# Altium schematic sheet s32k144开发板总图.SchDoc(s32k144开发板总图)
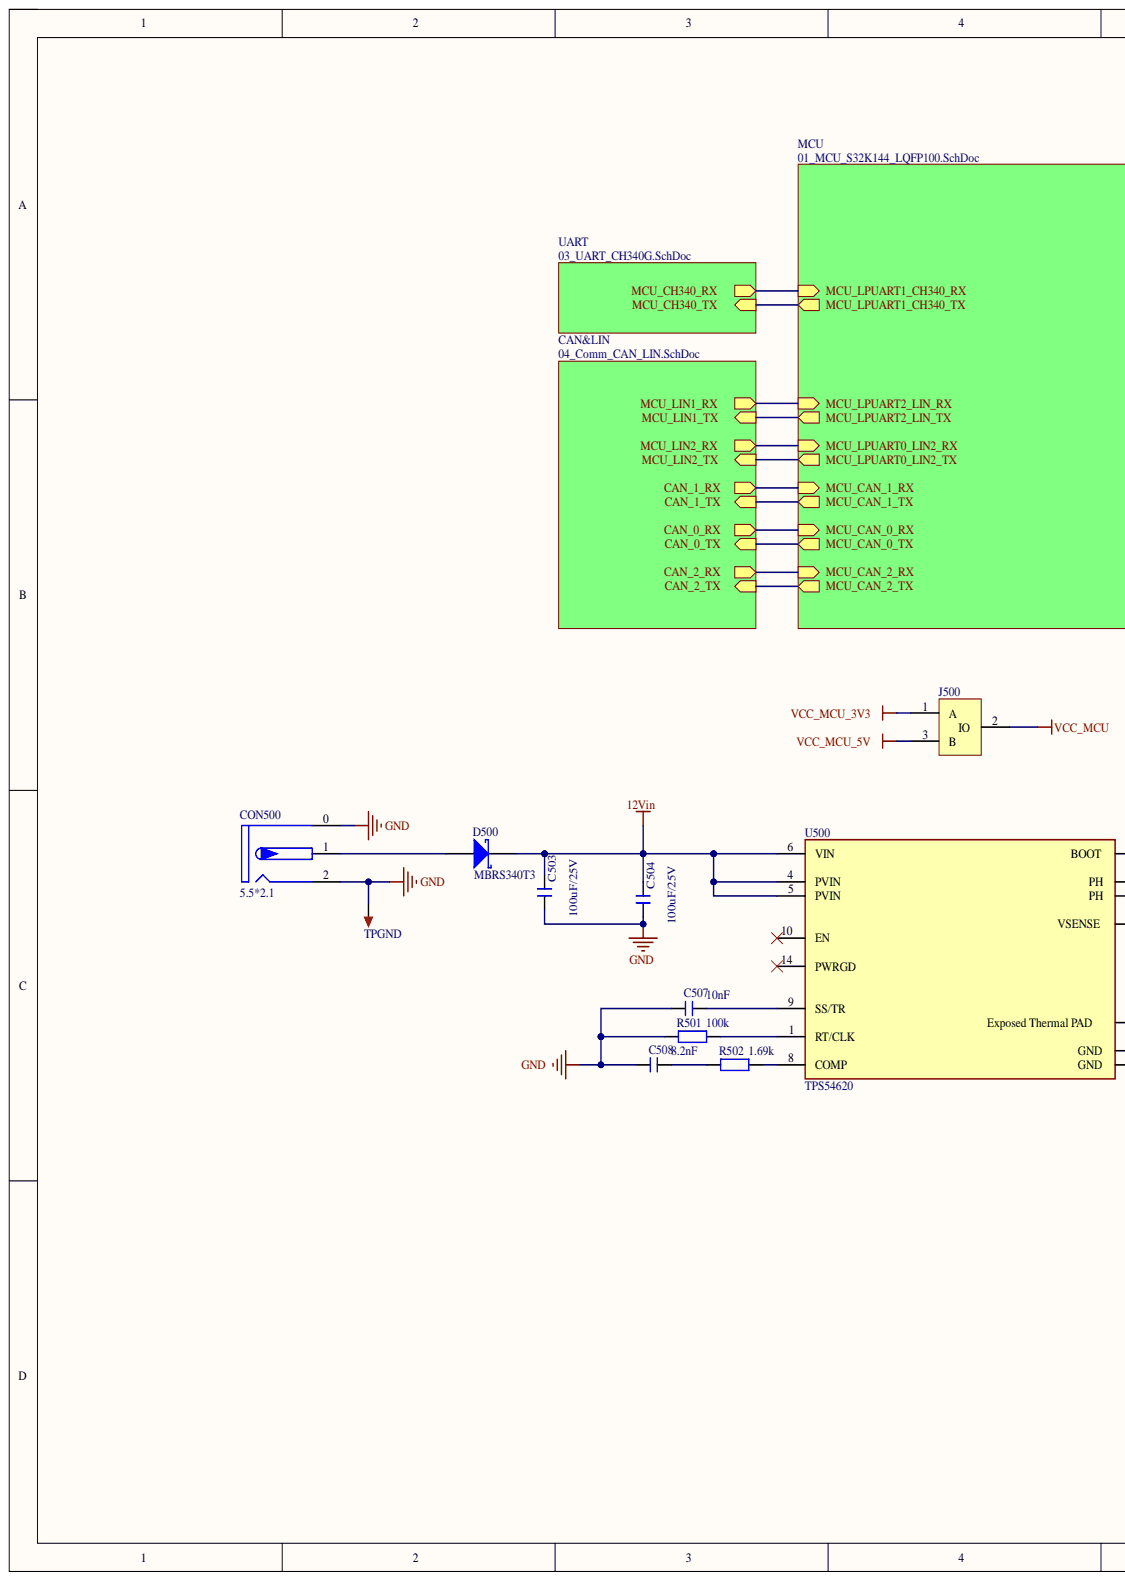
[diagram p0: s32k144开发板总图.SchDoc, left]
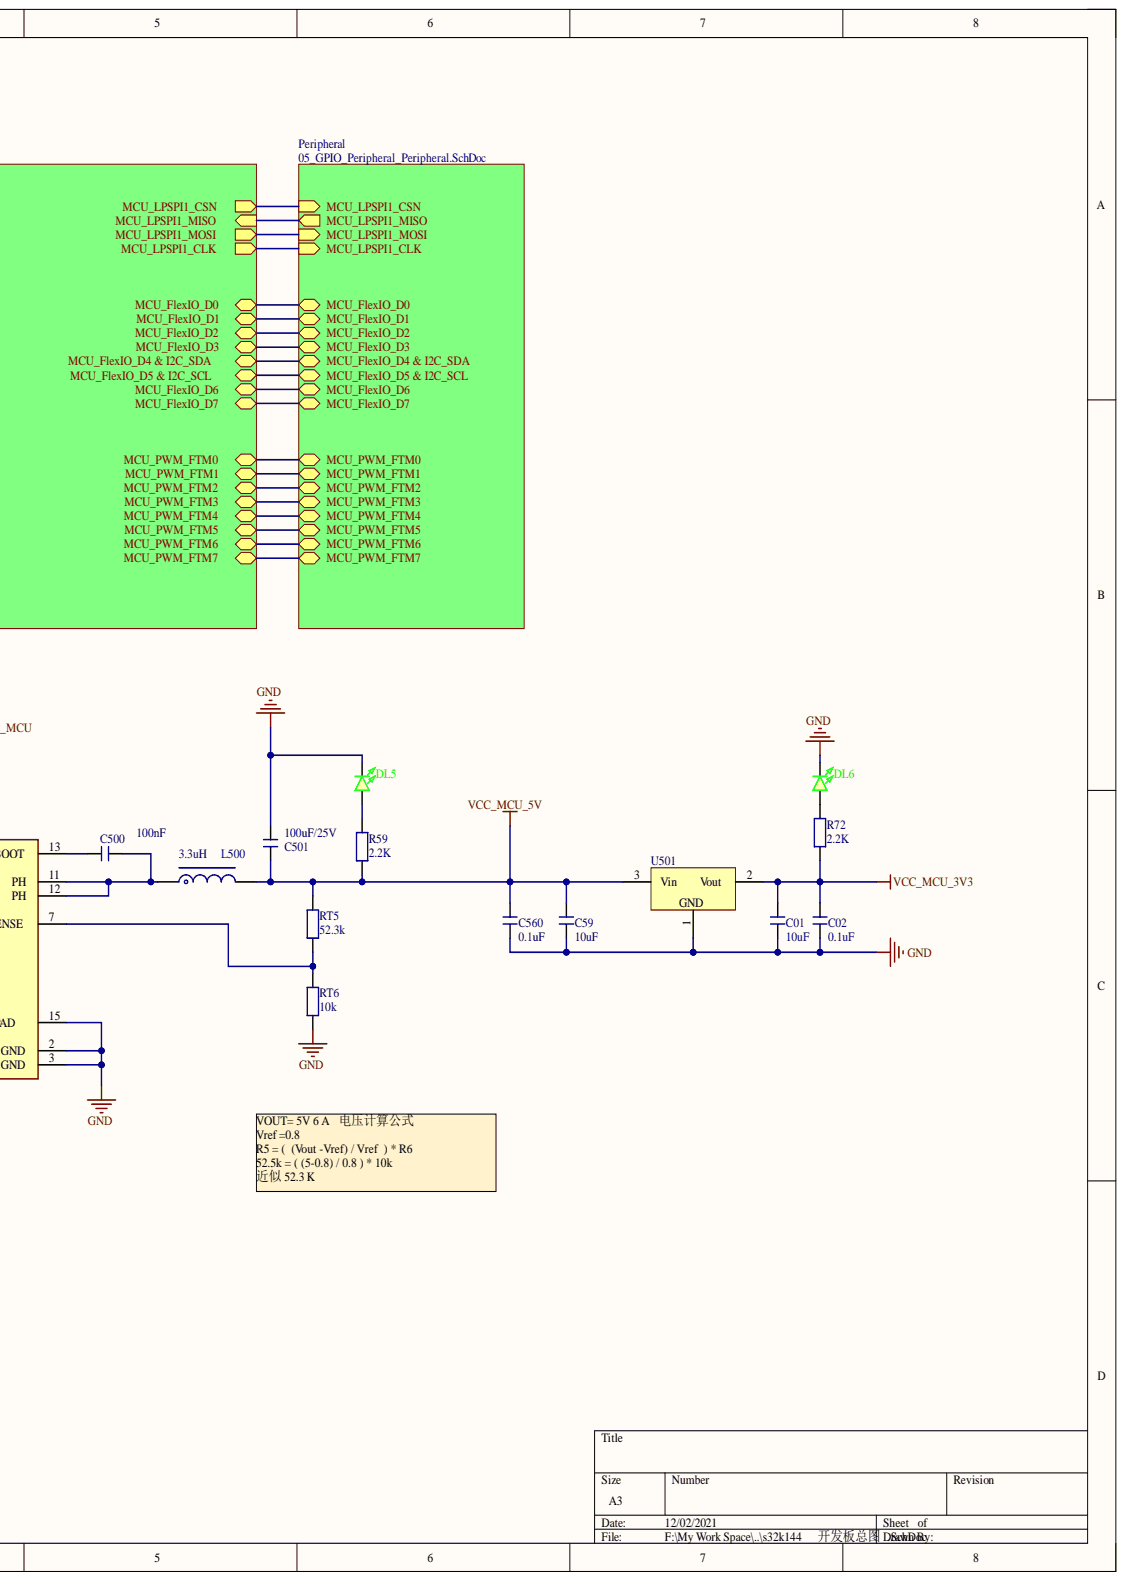
[diagram p1: s32k144开发板总图.SchDoc, right]

## Parts
C01  10uF  [C 0805_L]
C02  0.1uF  [C 0603_L]
C59  10uF  [C 0805_L]
C500  100nF  [C 0603_L]
C501  100uF/25V  [C 1210_L]
C503  100uF/25V  [C 1210_L]
C504  100uF/25V  [C 1210_L]
C507  10nF  [C 0603_L]
C508  8.2nF  [C 0603_L]
C560  0.1uF  [C 0603_L]
CON500  5.5*2.1  [DC-005P]
D500  MBRS340T3  [SMC]
DL5  (no value)  [LED 0603B]
DL6  (no value)  [LED 0603B]
J500  CON3_Jump_1  [HDR2.54-LI-3P]  (value not drawn)
L500  3.3uH  [L-SMD-0630]
R59  2.2K  [R 0603_L]
R72  2.2K  [R 0603_L]
R501  100k  [R 0603_L]
R502  1.69k  [R 0603_L]
RT5  52.3k  [R 0603_L]
RT6  10k  [R 0603_L]
TPGND  TEST TP  [TEST TP1.4_B]  (value not drawn)
U500  TPS54620  [tps54620]
U501  AMS1117-3V3  [SOT223_L]  (value not drawn)

## Nets
12Vin: C503.2 C504.2 D500.2 U500.4(PVIN) U500.5(PVIN) U500.6(VIN)
GND: C01.1 C02.1 C59.1 C501.2 C503.1 C504.1 C507.1 C508.1 C560.1 CON500.0 CON500.2 DL5.2 DL6.2 R501.2 RT6.2 TPGND.1 U500.2(GND) U500.3(GND) U500.15(Exposed_Thermal_PAD) U501.1
VCC_MCU: J500.2
VCC_MCU_3V3: C01.2 C02.2 J500.1 R72.2 U501.2
VCC_MCU_5V: C59.2 C501.1 C560.2 J500.3 L500.2 R59.1 RT5.1 U501.3
NetC500_1: C500.1 U500.13(BOOT)
NetC500_2: C500.2 L500.1 U500.11(PH) U500.12(PH)
NetC507_2: C507.2 U500.9(SS/TR)
NetC508_2: C508.2 R502.2
NetCON500_1: CON500.1 D500.1
NetDL5_1: DL5.1 R59.2
NetDL6_1: DL6.1 R72.1
NetR501_1: R501.1 U500.1(RT/CLK)
NetR502_1: R502.1 U500.8(COMP)
NetRT5_2: RT5.2 RT6.1 U500.7(VSENSE)
NetU500_10: U500.10(EN)
NetU500_14: U500.14(PWRGD)
CAN_0_RX:
CAN_0_TX:
CAN_1_RX:
CAN_1_TX:
CAN_2_RX:
CAN_2_TX:
MCU_CH340_RX:
MCU_CH340_TX:
MCU_FlexIO_D0:
MCU_FlexIO_D1:
MCU_FlexIO_D2:
MCU_FlexIO_D3:
MCU_FlexIO_D4 & I2C_SDA:
MCU_FlexIO_D5 & I2C_SCL:
MCU_FlexIO_D6:
MCU_FlexIO_D7:
MCU_LIN1_RX:
MCU_LIN1_TX:
MCU_LIN2_RX:
MCU_LIN2_TX:
MCU_LPSPI1_CLK:
MCU_LPSPI1_CSN:
MCU_LPSPI1_MISO:
MCU_LPSPI1_MOSI:
MCU_PWM_FTM0:
MCU_PWM_FTM1:
MCU_PWM_FTM2:
MCU_PWM_FTM3:
MCU_PWM_FTM4:
MCU_PWM_FTM5:
MCU_PWM_FTM6:
MCU_PWM_FTM7:

## Library data of the parts
C01 C02 C59 C500 C501 C503 C504 C507 C508 C560 = C: 贴片电容; BOM名称: 无极性贴片电容; 淘宝ID: 封装库来自淘宝 ID：黄晶宝石; Component Kind: Standard; Library Name: 0.1 - 电阻-电容-电感.SchLib; 唯一出售店铺网址: https://shop463601444.taobao.com; 联系售后，技术支持: https://shop463601444.taobao.com
CON500 = DC-005P: 带屏蔽DC电源接口; BOM名称: 带屏蔽DC电源接口; Component Kind: Standard; Library Name: 0.8 - 以太网-音频-USB插座.SchLib; 学习资源: https://shop463601444.taobao.com
D500 = SS34: 肖特基二极管; 属性: 40V/3A; BOM名称: 肖特基二极管; Component Kind: Standard; Library Name: 0.2 -  二极管-整流桥.SchLib; 封装库来自淘宝 ID：黄晶宝石: https://shop463601444.taobao.com
DL5 DL6 = LED-SMD: 贴片LED; 版权归属: 封装库来自淘宝 ID：黄晶宝石; BOM名称: 贴片LED; Component Kind: Standard; Library Name: 0.3 - LED发光二极管.SchLib; 学习资源: https://shop463601444.taobao.com
L500 = L-CDRH: 功率屏蔽电感; 版权归属: 封装库来自淘宝 ID：黄晶宝石; BOM名称: 功率屏蔽电感; Component Kind: Standard; Library Name: 0.1 - 电阻-电容-电感.SchLib; 联系售后: https://shop463601444.taobao.com
R59 R72 R501 R502 RT5 RT6 = R: 贴片电阻; 封装库来自淘宝卖家 ID：黄晶宝石: 其他店铺购入均为盗版，不享受售后技术支持服务，请立即申请退款; 版权归属: 来自淘宝 ID：黄晶宝石; BOM名称: 贴片电阻; Component Kind: Standard; Library Name: 0.1 - 电阻-电容-电感.SchLib; 联系售后: https://shop463601444.taobao.com
TPGND = TEST TP: 测试环; 版权归属: 封装库来自淘宝 ID：黄晶宝石; BOM名称: 测试环; Component Kind: Standard; Library Name: 4.1 - 未分类器件.SchLib; 学习资源: https://shop463601444.taobao.com
U500 = TPS54620: TI 电源芯片 PMIC; Component Kind: Standard; Library Name: 自用原理图库.SchLib
U501 = AMS1117: 三端稳压芯片; BOM名称: 稳压芯片; 淘宝ID: 封装库来自淘宝 ID：黄晶宝石; Component Kind: Standard; Library Name: 1.1 - 电源类芯片.SchLib; 欢迎光临淘宝店铺: https://shop463601444.taobao.com
J500 = CON3_Jump_1: Component Kind: Standard; Library Name: 自用原理图库.SchLib
